# Altium schematic sheet Transceiver.SchDoc(Transceiver)
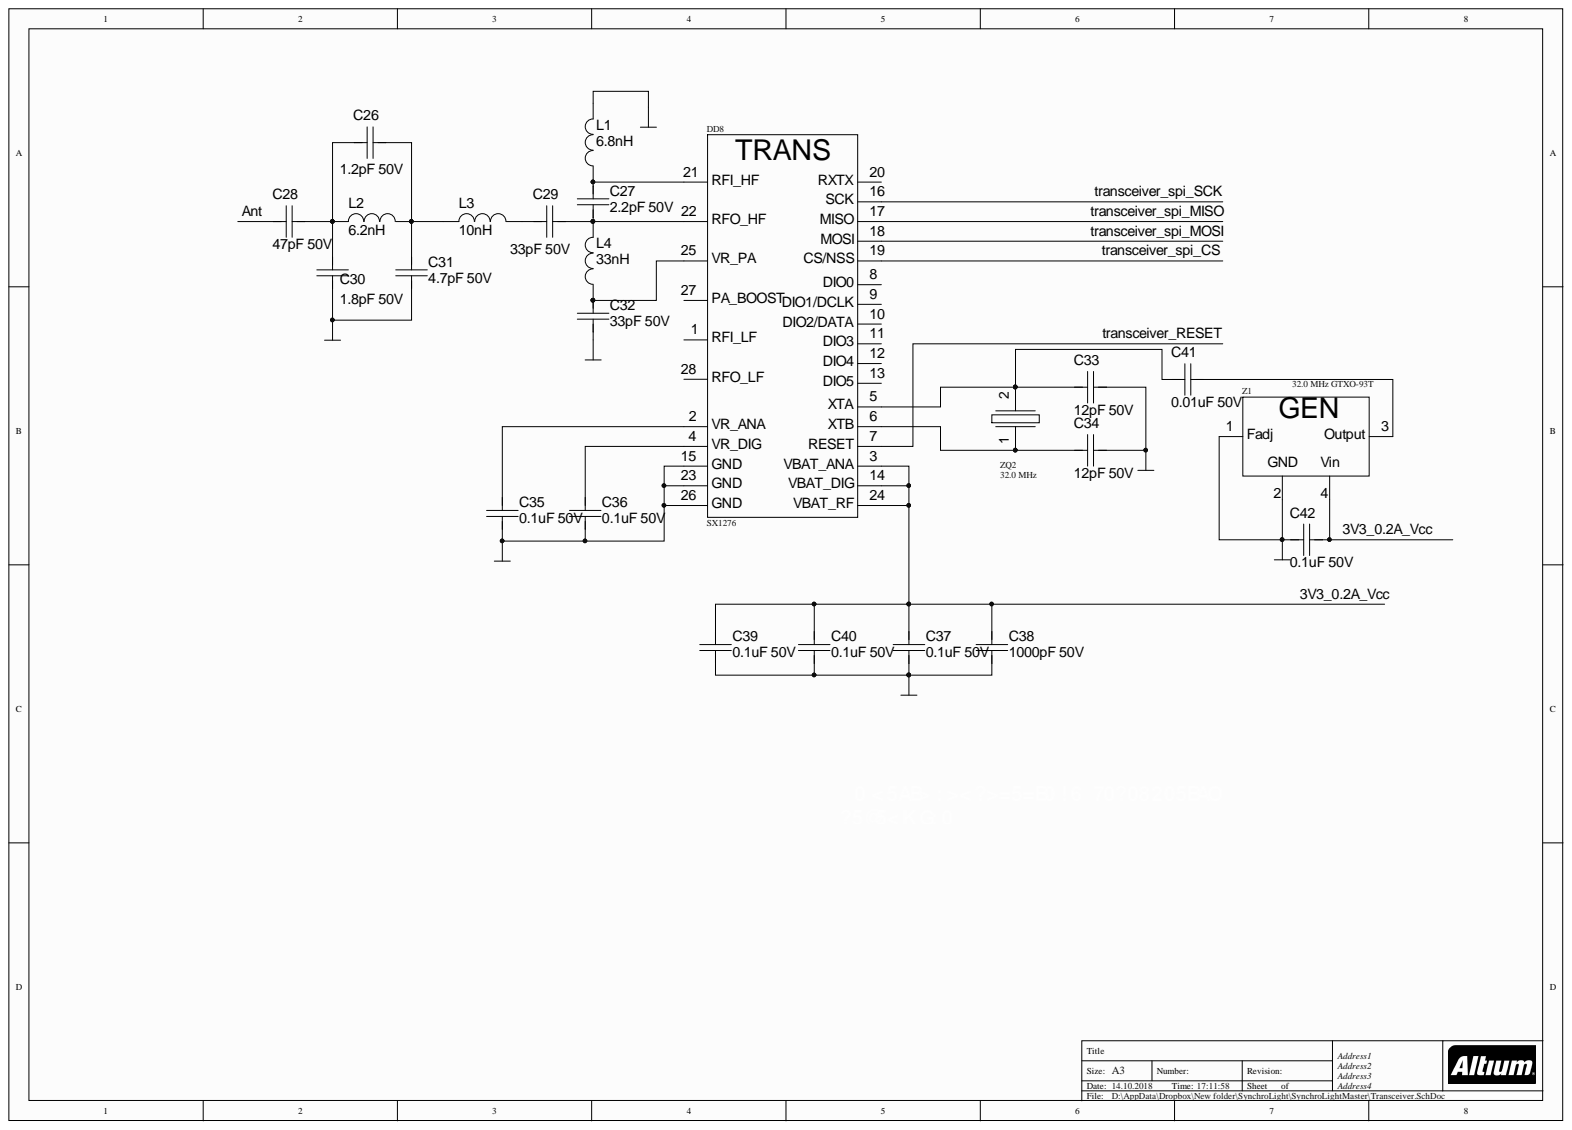
[diagram p0: Transceiver.SchDoc]

## Parts
C26  1.2pF 50V  [0603_CAP]
C27  2.2pF 50V  [0603_CAP]
C28  47pF 50V  [0603_CAP]
C29  33pF 50V  [0603_CAP]
C30  1.8pF 50V  [0603_CAP]
C31  4.7pF 50V  [0603_CAP]
C32  33pF 50V  [0603_CAP]
C33  12pF 50V  [0805_CAP]
C34  12pF 50V  [0805_CAP]
C35  0.1uF 50V  [0805_CAP]
C36  0.1uF 50V  [0805_CAP]
C37  0.1uF 50V  [0805_CAP]
C38  1000pF 50V  [0805_CAP]
C39  0.1uF 50V  [0805_CAP]  (value not drawn)
C40  0.1uF 50V  [0805_CAP]
C41  0.01uF 50V  [0805_CAP]
C42  0.1uF 50V  [0805_CAP]
DD8  SX1276  [qfn28]
L1  6.8nH  [0603_ind]
L2  6.2nH  [0603_ind]
L3  10nH  [0603_ind]
L4  33nH  [0603_ind]
Z1  32.0 MHz GTXO-93T  [gtxo-93]
ZQ2  32.0 MHz  [HC-49]

## Nets
GND: C30.1 C31.2 C32.2 C33.2 C34.2 C35.1 C36.2 C37.2 C38.2 C39.2 C40.2 C42.1 DD8.15(GND) DD8.23(GND) DD8.26(GND) L1.2 Z1.1(Fadj) Z1.2
3V3_0.2A_Vcc: C37.1 C38.1 C39.1 C40.1 C42.2 DD8.3(VBAT_ANA) DD8.14(VBAT_DIG) DD8.24(VBAT_RF) Z1.4
Ant: C28.2
transceiver_RESET: DD8.7(RESET)
transceiver_spi_CS: DD8.19(CS/NSS)
transceiver_spi_MISO: DD8.17(MISO)
transceiver_spi_MOSI: DD8.18(MOSI)
transceiver_spi_SCK: DD8.16(SCK)
NetC26_1: C26.1 C28.1 C30.2 L2.1
NetC26_2: C26.2 C31.1 L2.2 L3.1
NetC27_1: C27.1 C29.1 DD8.22(RFO_HF) L4.2
NetC27_2: C27.2 DD8.21(RFI_HF) L1.1
NetC29_2: C29.2 L3.2
NetC32_1: C32.1 DD8.25(VR_PA) L4.1
NetC33_1: C33.1 C41.1 DD8.5(XTA) ZQ2.2
NetC34_1: C34.1 DD8.6(XTB) ZQ2.1
NetC35_2: C35.2 DD8.2(VR_ANA)
NetC36_1: C36.1 DD8.4(VR_DIG)
NetC41_2: C41.2 Z1.3(Output)

Not connected: DD8 1(RFI_LF) 8(DIO0) 9(DIO1/DCLK) 10(DIO2/DATA) 11(DIO3) 12(DIO4) 13(DIO5) 20(RXTX) 27(PA_BOOST) 28(RFO_LF)

## Library data of the parts
C26-C42 = Cap_np: Component Kind: Standard; Library Name: Integrated_Library_tikhovikt.IntLib
  C26: Value: 1.2pF 50V
  C27: Value: 2.2pF 50V
  C28: Value: 47pF 50V
  C29 C32: Value: 33pF 50V
  C30: Value: 1.8pF 50V
  C31: Value: 4.7pF 50V
  C33 C34: Value: 12pF 50V
  C35-C37 C39 C40 C42: Value: 0.1uF 50V
  C38: Value: 1000pF 50V
  C41: Value: 0.01uF 50V
L1-L4 = Inductor: Component Kind: Standard; Library Name: Integrated_Library_tikhovikt.IntLib
  L1: Value: 6.8nH
  L2: Value: 6.2nH
  L3: Value: 10nH
  L4: Value: 33nH
Z1 = gtxo-93v: Value: 32.0 MHz GTXO-93T; Component Kind: Standard; Library Name: Integrated_Library_tikhovikt.IntLib
ZQ2 = Cristall: Value: 32.0 MHz; Component Kind: Standard; Library Name: Integrated_Library_tikhovikt.IntLib
DD8 = SX1276: Value: SX1276; Component Kind: Standard; Library Name: Integrated_Library_tikhovikt.IntLib
